# Altium schematic sheet Ethernet.SchDoc(Ethernet)
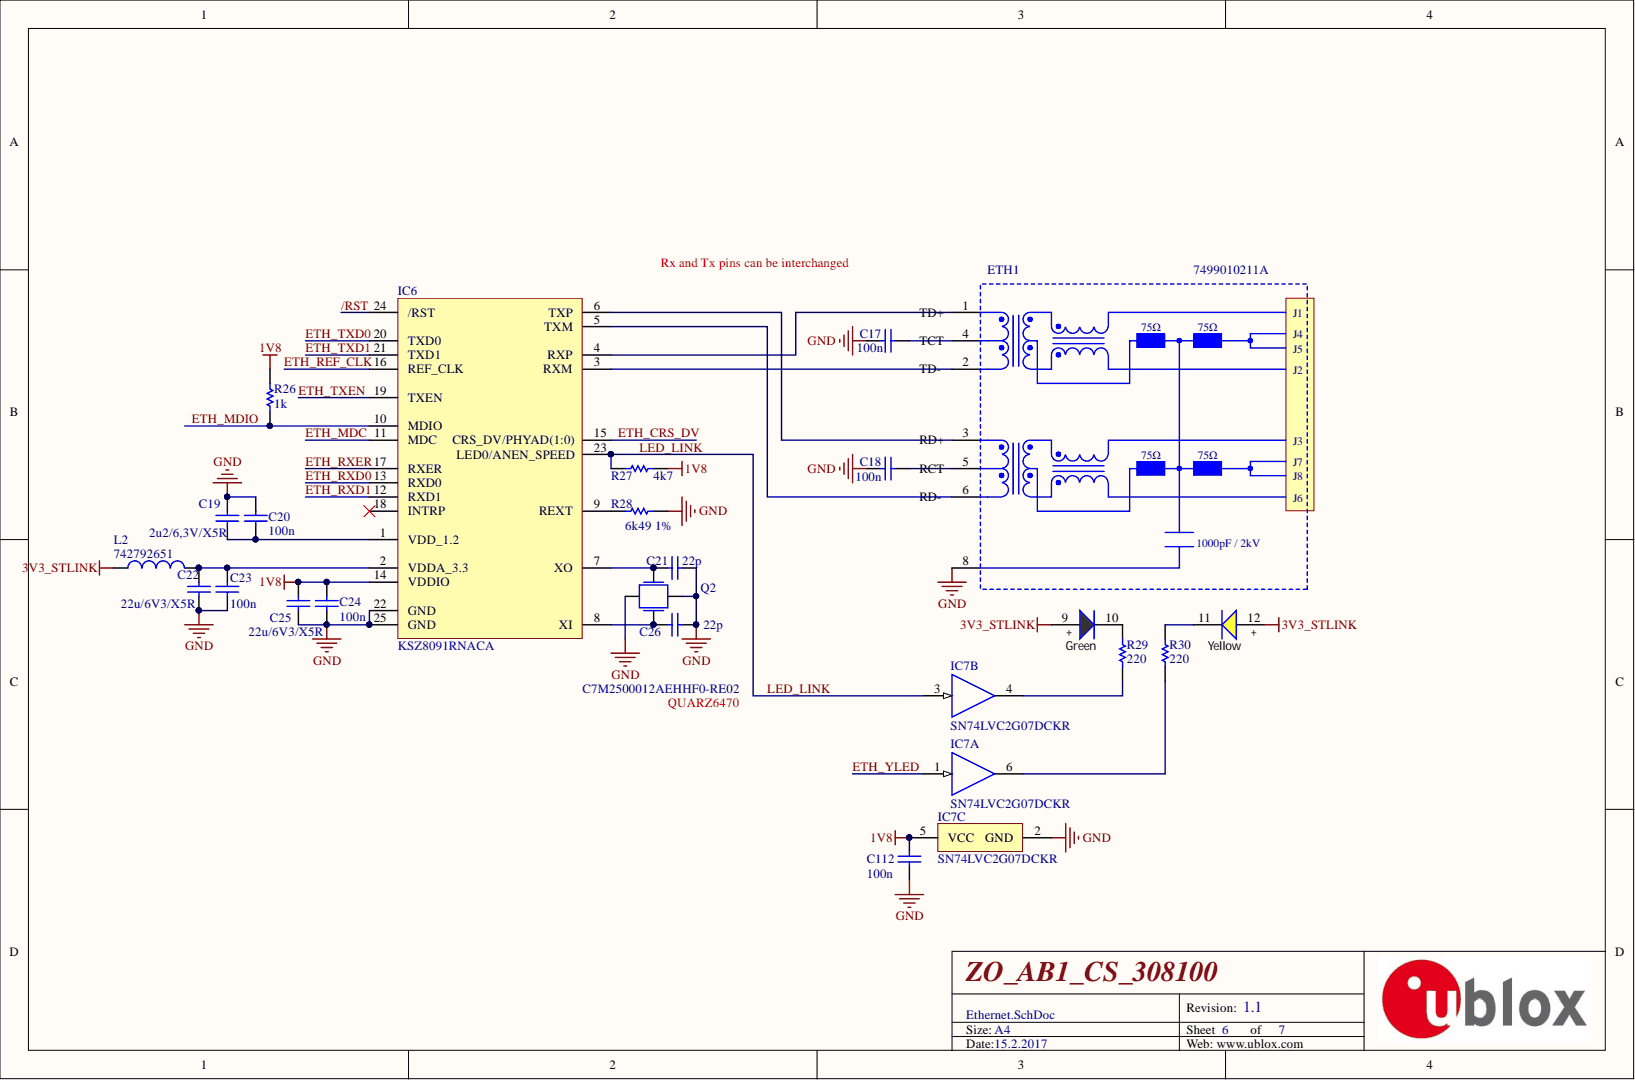
[diagram p0: Ethernet.SchDoc]

## Parts
C17  100n  [C0402]
C18  100n  [C0402]
C19  2u2/6,3V/X5R  [C0402]
C20  100n  [C0402]
C21  22p  [C0402]
C22  22u/6V3/X5R  [C0603]
C23  100n  [C0402]
C24  100n  [C0402]
C25  22u/6V3/X5R  [C0603]
C26  22p  [C0402]
C112  100n  [C0402]
ETH1  7499010211A  [7499010211A]
IC6  KSZ8091RNACA  [QFN24]
IC7  SN74LVC2G07DCKR  [DCK0006A_N]  (parts A,B,C)
L2  742792651  [L0603]
Q2  C7M2500012AEHHF0-RE02  [Q3225]
R26  1k  [R0402]
R27  4k7  [R0402]
R28  6k49 1%  [R0402]
R29  220  [R0402]
R30  220  [R0402]

## Nets
1V8: C24.2 C25.2 C112.2 IC6.14(VDDIO) IC7.5(VCC) R26.2 R27.1
3V3_STLINK: ETH1.9 ETH1.12 L2.1
GND: C17.2 C18.2 C19.2 C20.2 C21.1 C22.1 C23.1 C24.1 C25.1 C26.1 C112.1 ETH1.8 IC6.22(GND) IC6.25(GND) IC7.2(GND) Q2.2 Q2.4 R28.1
/RST: IC6.24(/RST)
ETH_CRS_DV: IC6.15(CRS_DV/PHYAD(1:0))
ETH_MDC: IC6.11(MDC)
ETH_MDIO: IC6.10(MDIO) R26.1
ETH_REF_CLK: IC6.16(REF_CLK)
ETH_RXD0: IC6.13(RXD0)
ETH_RXD1: IC6.12(RXD1)
ETH_RXER: IC6.17(RXER)
ETH_TXD0: IC6.20(TXD0)
ETH_TXD1: IC6.21(TXD1)
ETH_TXEN: IC6.19(TXEN)
ETH_YLED: IC7.1
LED_LINK: IC6.23(LED0/ANEN_SPEED) IC7.3 R27.2
NetC17_1: C17.1 ETH1.4
NetC18_1: C18.1 ETH1.5
NetC19_1: C19.1 C20.1 IC6.1(VDD_1.2)
NetC21_2: C21.2 IC6.7(XO) Q2.3
NetC22_2: C22.2 C23.2 IC6.2(VDDA_3.3) L2.2
NetC26_2: C26.2 IC6.8(XI) Q2.1
NetETH1_1: ETH1.1 IC6.4(RXP)
NetETH1_2: ETH1.2 IC6.3(RXM)
NetETH1_3: ETH1.3 IC6.6(TXP)
NetETH1_6: ETH1.6 IC6.5(TXM)
NetETH1_10: ETH1.10 R29.2
NetETH1_11: ETH1.11 R30.2
NetIC6_9: IC6.9(REXT) R28.2
NetIC7_4: IC7.4 R29.1
NetIC7_6: IC7.6 R30.1

Not connected: IC6 18(INTRP)

## Library data of the parts
C17-C26 C112 = Capacitor SMD: SMD chip capacitor; Value: Xn; Component Kind: Standard; LatestRevisionDate: 26-Dec-2008; Published: 26-Dec-2008; Signal Integrity: Cap; Simulation: CAP; Library Name: BorisPassive.SchLib; Publisher: www.poscope.com
ETH1 = 7499010211A: WE-RJ45LAN 10/100BaseT; Manufacturer: Wurth Elektronik; ComponentLink1 Description: Manufacturer; ComponentLink2 Description: Datasheet; LED (Left-Right): Green/Yellow; Operation Temperature: 0 °C up to +70 °C; Ports: 1x1; Speed: 10/100 Base-T; Turns Ratio: 1:1; USB: No; Application: Standard; Component Kind: Standard; ComponentLink1 URL: http://www.we-online.com; ComponentLink2 URL: http://katalog.we-online.de/pbs/datasheet/7499010211A.pdf; Created Date: April 2016; Library Name: ALTIUM-WE-LAN-RJ45-rev16c.IntLib; PartNo: 7499010211A; Created By: Baojun Chen
IC6 = KSZ8081RNA: 10 base-T/100Base-TX PHY with RMII Support; Component Kind: Standard; Library Name: BorisIC1.SchLib
IC7 = SN74LVC2G07DCKR: Dual Buffer/Driver with Open-Drain Output, DCK0006A, LARGE T&R; Manufacturer: Texas Instruments; 3-State Output: No; Bits(#): 2; F @ Nom Voltage(Max)(Mhz): 150; ICC @ Nom Voltage(Max)(mA): 0.01; Input Type: CMOS/TTL; Logic: True; Output Drive (IOL/IOH)(Max)(mA): 32/-32; Output Type: CMOS; Rating: Catalog; Sub-Family: Inverting Buffer/Driver; Technology Family: LVC; tpd @ Nom Voltage(Max)(ns): 8.6,4.4,3.7,2.9; VCC(Max)(V): 5.5; VCC(Min)(V): 1.65; Voltage(Nom)(V): 1.8,2.5,3.3,5; Component Kind: Standard; ComponentLink1Description: Manufacturer URL; ComponentLink1URL: http://www.ti.com/; ComponentLink2Description: Datasheet; ComponentLink2URL: http://www.ti.com/lit/ds/symlink/sn74lvc2g07.pdf; DatasheetVersion: SCES308K; Generic Part Number: SN74LVC2G07; Library Name: TI_Logic_Little_Logic.IntLib; Operating Temperature Range(C): -40 to 125; PackageReference: DCK0006A; PartNumber: SN74LVC2G07DCKR; Schmitt Trigger: No; Supplier 1: Mouser; Supplier 2: Digi-Key; Supplier 3: Digi-Key; Supplier 4: Digi-Key; Supplier Part Number 1: 595-SN74LVC2G07DCKR; Supplier Part Number 2: 296-13495-2-ND; Supplier Part Number 3: 296-13495-1-ND; Supplier Part Number 4: 296-13495-6-ND
L2 = Inductor SMD: Value: XuH; Component Kind: Standard; LatestRevisionDate: 21 March 2009; Published: 21 March 2009; Library Name: BorisPassive.SchLib; Publisher: www.poscope.com
Q2 = Crystal Quarz: Quarz crystals; Component Kind: Standard; Library Name: BorisPassive.SchLib
R26-R30 = Resistor SMD: SMD chip resistor; Value: Xk; Component Kind: Standard; LatestRevisionDate: 26-Dec-2008; Published: 26-Dec-2008; Signal Integrity: Res Semi; Simulation: RES; Library Name: BorisPassive.SchLib; Publisher: www.poscope.com
